# Altium schematic sheet 01 STM32.SchDoc
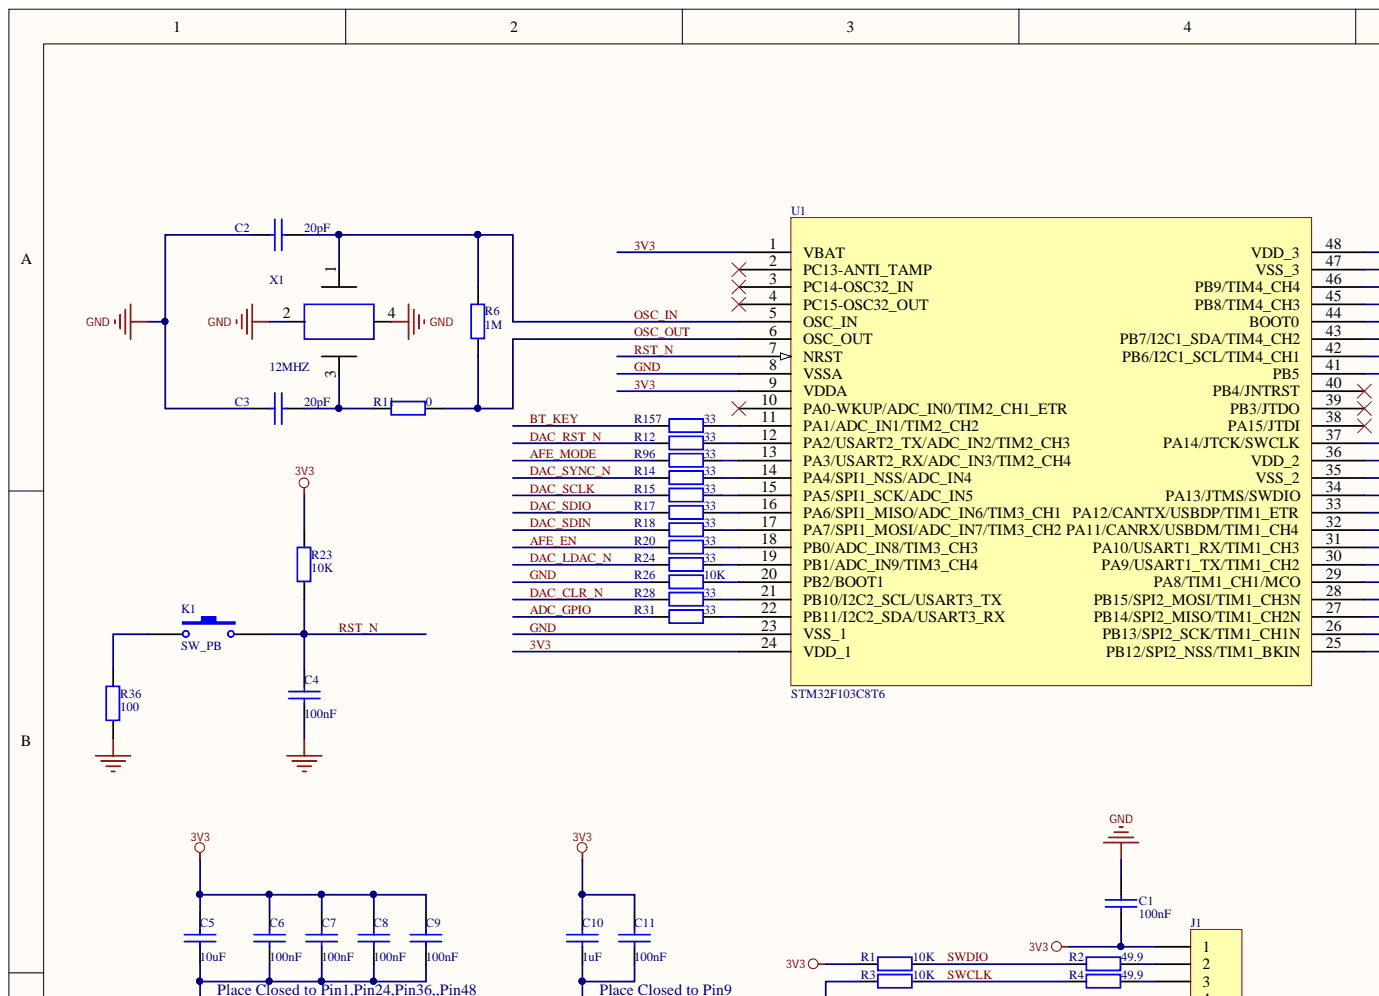
[diagram p0: 01 STM32.SchDoc, top left]
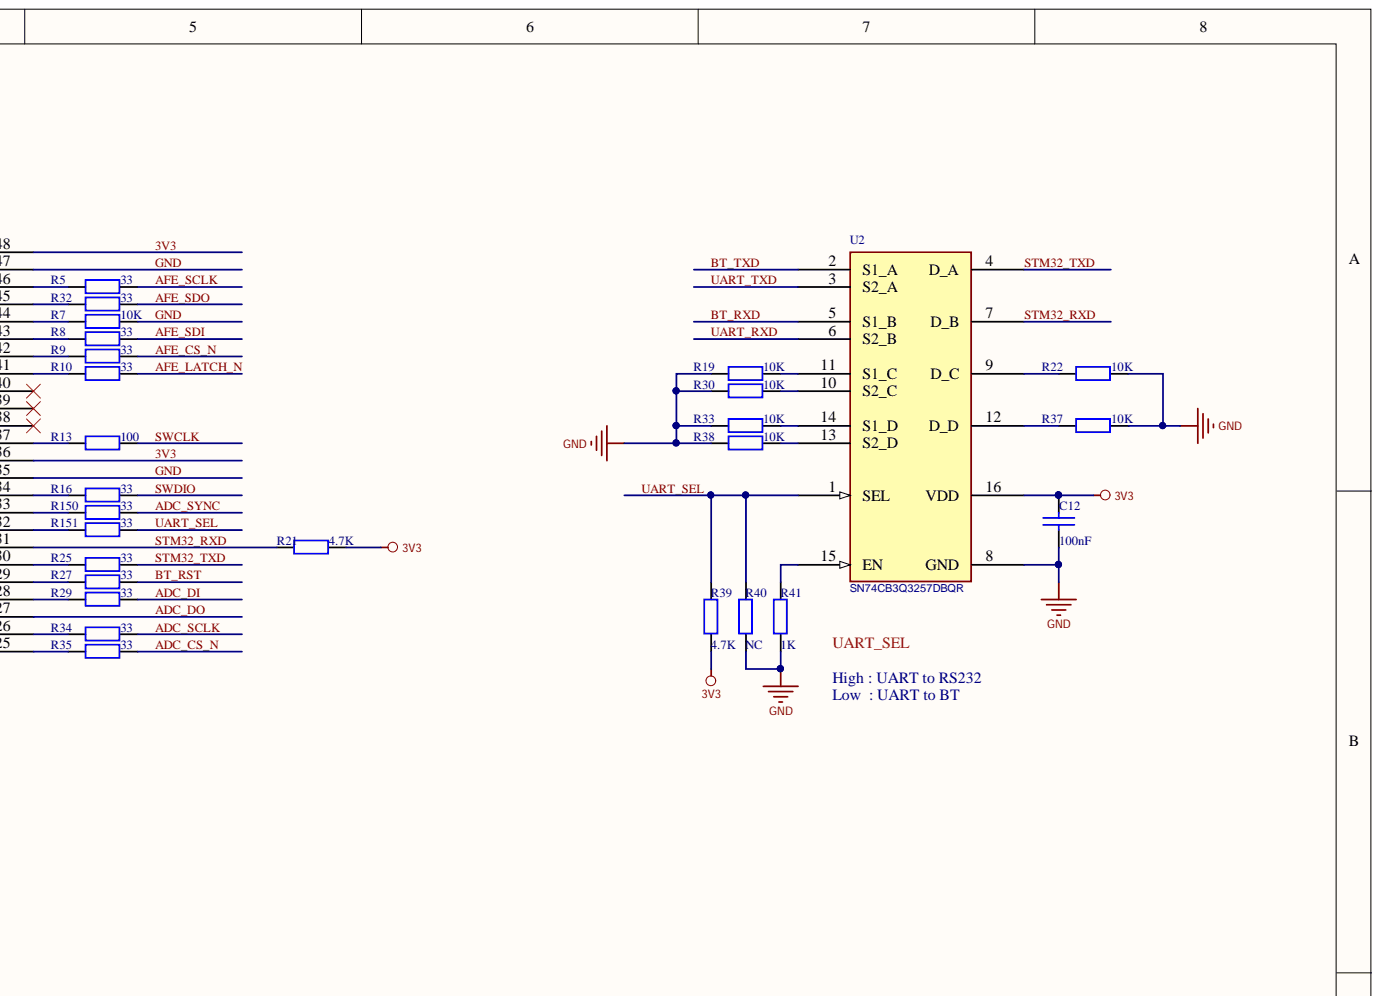
[diagram p1: 01 STM32.SchDoc, top right]
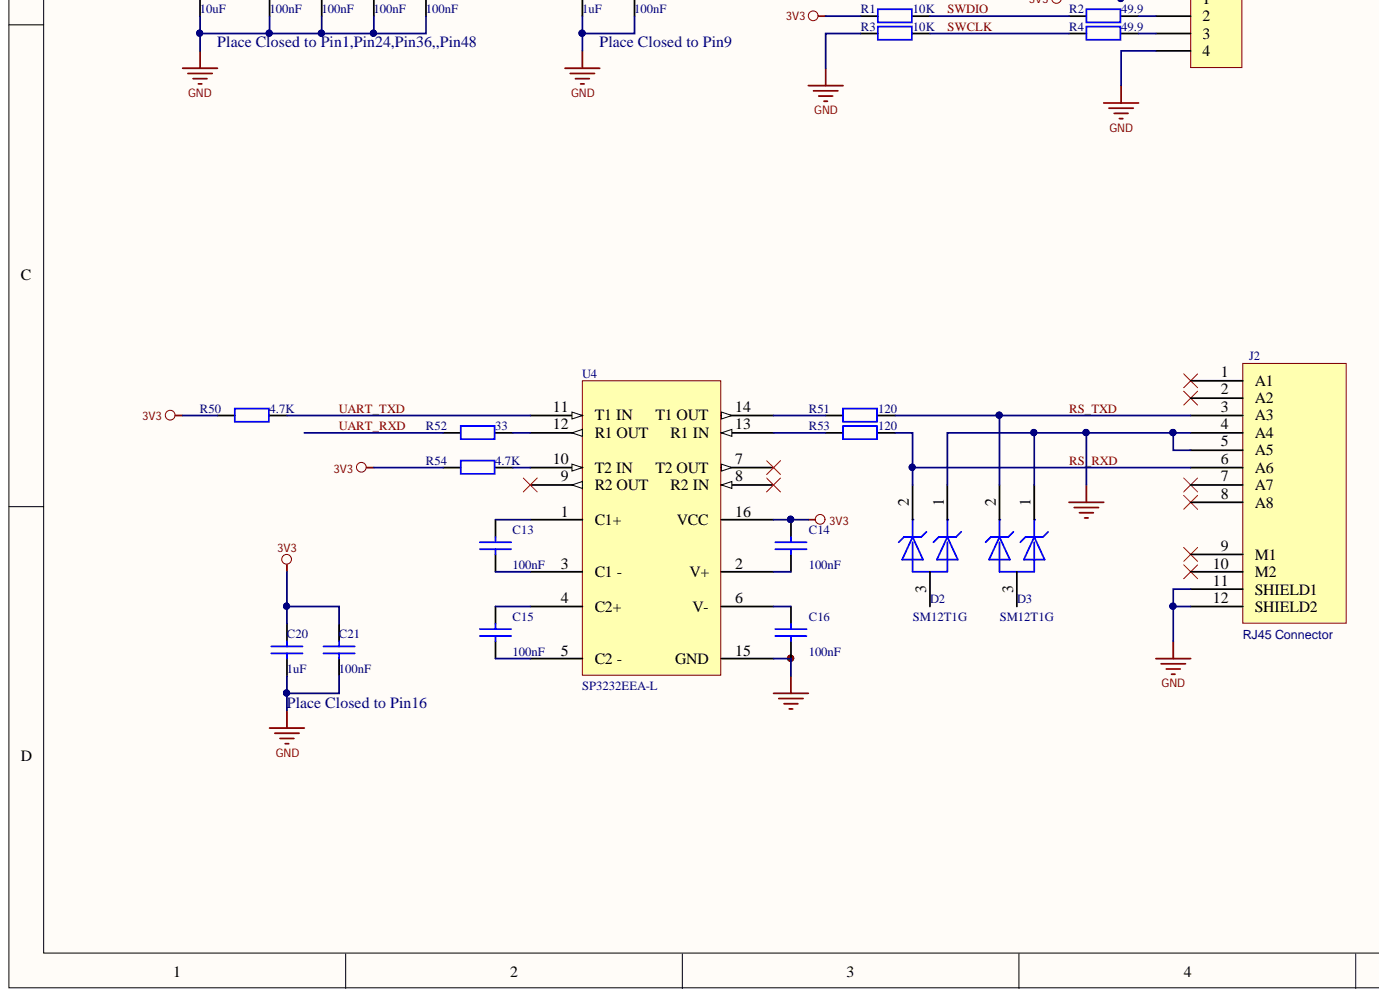
[diagram p2: 01 STM32.SchDoc, bottom left]
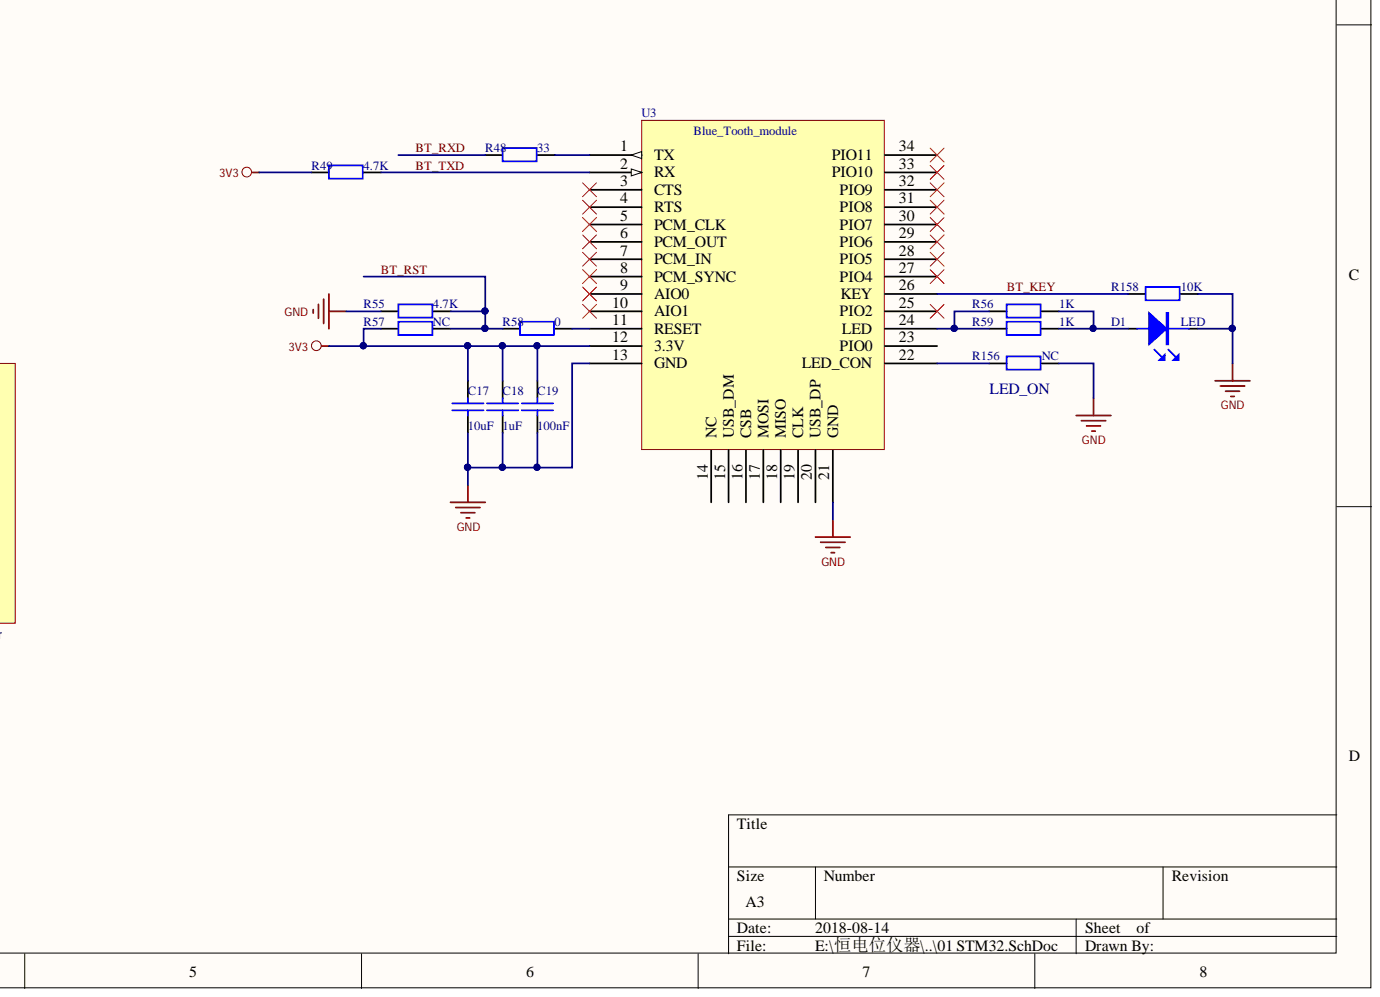
[diagram p3: 01 STM32.SchDoc, bottom right]

## Parts
C1  100nF  [SC0402]
C2  20pF  [SC0603]
C3  20pF  [SC0603]
C4  100nF  [SC0402]
C5  10uF  [SC0603]
C6  100nF  [SC0402]
C7  100nF  [SC0402]
C8  100nF  [SC0402]
C9  100nF  [SC0402]
C10  1uF  [SC0402]
C11  100nF  [SC0402]
C12  100nF  [SC0402]
C13  100nF  [SC0603]
C14  100nF  [SC0603]
C15  100nF  [SC0603]
C16  100nF  [SC0603]
C17  10uF  [SC0603]
C18  1uF  [SC0402]
C19  100nF  [SC0402]
C20  1uF  [SC0402]
C21  100nF  [SC0402]
D1  LED  [SD0603-LED]
D2  value SM12T1G  [SOT23]  (hidden comment: ZEN TVS ARRAY)
D3  value SM12T1G  [SOT23]  (hidden comment: ZEN TVS ARRAY)
J1  插针-4PIN-单排-2.54MM  [4PIN_254MM]  (value not drawn)
J2  RJ45 Connector  [MJ8-SRLFY]
K1  SW_PB  [SMT2]
R1  10K±1%  value 10K  [SR0402]
R2  49.9±1%  value 49.9  [SR0402]
R3  10K±1%  value 10K  [SR0402]
R4  value 49.9  [SR0402]  (hidden comment: 49.9±1%)
R5  value 33  [SR0402]  (hidden comment: 33±1%)
R6  value 1M  [SR0402]  (hidden comment: 1M±1%)
R7  value 10K  [SR0402]  (hidden comment: 10K±1%)
R8  value 33  [SR0402]  (hidden comment: 33±1%)
R9  value 33  [SR0402]  (hidden comment: 33±1%)
R10  value 33  [SR0402]  (hidden comment: 33±1%)
R11  value 0  [SR0402]  (hidden comment: 0±5%)
R12  value 33  [SR0402]  (hidden comment: 33±1%)
R13  value 100  [SR0402]  (hidden comment: 100±1%)
R14  value 33  [SR0402]  (hidden comment: 33±1%)
R15  value 33  [SR0402]  (hidden comment: 33±1%)
R16  value 33  [SR0402]  (hidden comment: 33±1%)
R17  value 33  [SR0402]  (hidden comment: 33±1%)
R18  value 33  [SR0402]  (hidden comment: 33±1%)
R19  10K±1%  value 10K  [SR0402]
R20  value 33  [SR0402]  (hidden comment: 33±1%)
R21  value 4.7K  [SR0402]  (hidden comment: 4.7K±1%)
R22  10K±1%  value 10K  [SR0402]
R23  value 10K  [SR0402]  (hidden comment: 10K±1%)
R24  value 33  [SR0402]  (hidden comment: 33±1%)
R25  value 33  [SR0402]  (hidden comment: 33±1%)
R26  value 10K  [SR0402]  (hidden comment: 10K±1%)
R27  value 33  [SR0402]  (hidden comment: 33±1%)
R28  value 33  [SR0402]  (hidden comment: 33±1%)
R29  value 33  [SR0402]  (hidden comment: 33±1%)
R30  10K±1%  value 10K  [SR0402]
R31  value 33  [SR0402]  (hidden comment: 33±1%)
R32  value 33  [SR0402]  (hidden comment: 33±1%)
R33  10K±1%  value 10K  [SR0402]
R34  value 33  [SR0402]  (hidden comment: 33±1%)
R35  value 33  [SR0402]  (hidden comment: 33±1%)
R36  value 100  [SR0402]  (hidden comment: 100±1%)
R37  value 10K  [SR0402]  (hidden comment: 10K±1%)
R38  10K±1%  value 10K  [SR0402]
R39  value 4.7K  [SR0402]  (hidden comment: 4.7K±1%)
R40  1K±1%  [SR0402]  (value not drawn)
R41  value 1K  [SR0402]  (hidden comment: 1K±1%)
R48  value 33  [SR0402]  (hidden comment: 33±1%)
R49  value 4.7K  [SR0402]  (hidden comment: 4.7K±1%)
R50  value 4.7K  [SR0402]  (hidden comment: 4.7K±1%)
R51  120±1%  value 120  [SR0603]
R52  value 33  [SR0402]  (hidden comment: 33±1%)
R53  120±1%  value 120  [SR0603]
R54  4.7K±1%  value 4.7K  [SR0402]
R55  value 4.7K  [SR0402]  (hidden comment: 4.7K±1%)
R56  value 1K  [SR0402]  (hidden comment: 1K±1%)
R57  4.7K±1%  [SR0402]  (value not drawn)
R58  value 0  [SR0402]  (hidden comment: 0±5%)
R59  value 1K  [SR0402]  (hidden comment: 1K±1%)
R96  value 33  [SR0402]  (hidden comment: 33±1%)
R150  value 33  [SR0402]  (hidden comment: 33±1%)
R151  value 33  [SR0402]  (hidden comment: 33±1%)
R156  4.7K±1%  [SR0402]  (value not drawn)
R157  value 33  [SR0402]  (hidden comment: 33±1%)
R158  value 10K  [SR0402]  (hidden comment: 10K±1%)
U1  STM32F103C8T6  [LQFP48]
U2  SN74CB3Q3257DBQR  [QSOP16]
U3  Blue_Tooth_module  [PKG_HC-06]
U4  SP3232EEA-L  [SSOP-16]
X1  12MHZ  [X288]

## Nets
3V3: C1.1 C5.2 C6.2 C7.2 C8.2 C9.2 C10.2 C11.2 C12.2 C14.1 C17.2 C18.2 C19.2 C20.2 C21.2 J1.1 R1.2 R21.2 R23.1 R39.2 R49.1 R50.1 R54.1 R57.1 U1.1(VBAT) U1.9(VDDA) U1.24(VDD_1) U1.36(VDD_2) U1.48(VDD_3) U2.16(VDD) U3.12(3.3V) U4.16(VCC)
ADC_CS_N: R35.2
ADC_DI: R29.2
ADC_DO: U1.27(PB14/SPI2_MISO/TIM1_CH2N)
ADC_GPIO: R31.1
ADC_SCLK: R34.2
ADC_SYNC: R150.2
AFE_CS_N: R9.2
AFE_EN: R20.1
AFE_LATCH_N: R10.2
AFE_MODE: R96.1
AFE_SCLK: R5.2
AFE_SDI: R8.2
AFE_SDO: R32.2
BT_KEY: R157.1 R158.2 U3.26(KEY)
BT_RST: R27.2 R55.2 R57.2 R58.1
BT_RXD: R48.1 U2.5(S1_B)
BT_TXD: R49.2 U2.2(S1_A) U3.2(RX)
DAC_CLR_N: R28.1
DAC_LDAC_N: R24.1
DAC_RST_N: R12.1
DAC_SCLK: R15.1
DAC_SDIN: R18.1
DAC_SDIO: R17.1
DAC_SYNC_N: R14.1
GND: C1.2 C2.1 C3.1 C4.1 C5.1 C6.1 C7.1 C8.1 C9.1 C10.1 C11.1 C12.1 C16.2 C17.1 C18.1 C19.1 C20.1 C21.1 D1.2 D2.1 D3.1 J1.4 J2.4(A4) J2.5(A5) J2.11(SHIELD1) J2.12(SHIELD2) R3.2 R7.1 R19.2 R22.1 R26.2 R30.2 R33.2 R36.1 R37.1 R38.2 R40.1 R41.1 R55.1 R156.2 R158.1 U1.8(VSSA) U1.23(VSS_1) U1.35(VSS_2) U1.47(VSS_3) U2.8(GND) U3.13(GND) U3.21(GND) U4.15(GND) X1.2 X1.4
OSC_IN: C2.2 R6.2 U1.5(OSC_IN) X1.1
OSC_OUT: R6.1 R11.2 U1.6(OSC_OUT)
RS_RXD: D2.2 J2.6(A6) R53.2
RS_TXD: D3.2 J2.3(A3) R51.2
RST_N: C4.2 K1.2 R23.2 U1.7(NRST)
STM32_RXD: R21.1 U1.31(PA10/USART1_RX/TIM1_CH3) U2.7(D_B)
STM32_TXD: R25.2 U2.4(D_A)
SWCLK: R3.1 R4.1 R13.1
SWDIO: R1.1 R2.1 R16.2
UART_RXD: R52.1 U2.6(S2_B)
UART_SEL: R39.1 R40.2 R151.2 U2.1(SEL)
UART_TXD: R50.2 U2.3(S2_A) U4.11(T1_IN)
NetC3_2: C3.2 R11.1 X1.3
NetC13_1: C13.1 U4.1(C1+)
NetC13_2: C13.2 U4.3(C1_-)
NetC14_2: C14.2 U4.2(V+)
NetC15_1: C15.1 U4.4(C2+)
NetC15_2: C15.2 U4.5(C2_-)
NetC16_1: C16.1 U4.6(V-)
NetD1_1: D1.1 R56.2 R59.2
NetJ1_2: J1.2 R2.2
NetJ1_3: J1.3 R4.2
NetK1_3: K1.3 R36.2
NetR5_1: R5.1 U1.46(PB9/TIM4_CH4)
NetR7_2: R7.2 U1.44(BOOT0)
NetR8_1: R8.1 U1.43(PB7/I2C1_SDA/TIM4_CH2)
NetR9_1: R9.1 U1.42(PB6/I2C1_SCL/TIM4_CH1)
NetR10_1: R10.1 U1.41(PB5)
NetR12_2: R12.2 U1.12(PA2/USART2_TX/ADC_IN2/TIM2_CH3)
NetR13_2: R13.2 U1.37(PA14/JTCK/SWCLK)
NetR14_2: R14.2 U1.14(PA4/SPI1_NSS/ADC_IN4)
NetR15_2: R15.2 U1.15(PA5/SPI1_SCK/ADC_IN5)
NetR16_1: R16.1 U1.34(PA13/JTMS/SWDIO)
NetR17_2: R17.2 U1.16(PA6/SPI1_MISO/ADC_IN6/TIM3_CH1)
NetR18_2: R18.2 U1.17(PA7/SPI1_MOSI/ADC_IN7/TIM3_CH2)
NetR19_1: R19.1 U2.11(S1_C)
NetR20_2: R20.2 U1.18(PB0/ADC_IN8/TIM3_CH3)
NetR22_2: R22.2 U2.9(D_C)
NetR24_2: R24.2 U1.19(PB1/ADC_IN9/TIM3_CH4)
NetR25_1: R25.1 U1.30(PA9/USART1_TX/TIM1_CH2)
NetR26_1: R26.1 U1.20(PB2/BOOT1)
NetR27_1: R27.1 U1.29(PA8/TIM1_CH1/MCO)
NetR28_2: R28.2 U1.21(PB10/I2C2_SCL/USART3_TX)
NetR29_1: R29.1 U1.28(PB15/SPI2_MOSI/TIM1_CH3N)
NetR30_1: R30.1 U2.10(S2_C)
NetR31_2: R31.2 U1.22(PB11/I2C2_SDA/USART3_RX)
NetR32_1: R32.1 U1.45(PB8/TIM4_CH3)
NetR33_1: R33.1 U2.14(S1_D)
NetR34_1: R34.1 U1.26(PB13/SPI2_SCK/TIM1_CH1N)
NetR35_1: R35.1 U1.25(PB12/SPI2_NSS/TIM1_BKIN)
NetR37_2: R37.2 U2.12(D_D)
NetR38_1: R38.1 U2.13(S2_D)
NetR41_2: R41.2 U2.15(EN)
NetR48_2: R48.2 U3.1(TX)
NetR51_1: R51.1 U4.14(T1_OUT)
NetR52_2: R52.2 U4.12(R1_OUT)
NetR53_1: R53.1 U4.13(R1_IN)
NetR54_2: R54.2 U4.10(T2_IN)
NetR56_1: R56.1 R59.1 U3.24(LED)
NetR58_2: R58.2 U3.11(RESET)
NetR96_2: R96.2 U1.13(PA3/USART2_RX/ADC_IN3/TIM2_CH4)
NetR150_1: R150.1 U1.33(PA12/CANTX/USBDP/TIM1_ETR)
NetR151_1: R151.1 U1.32(PA11/CANRX/USBDM/TIM1_CH4)
NetR156_1: R156.1 U3.22(LED_CON)
NetR157_2: R157.2 U1.11(PA1/ADC_IN1/TIM2_CH2)

Not connected: D2 3; D3 3; J2 1(A1) 2(A2) 7(A7) 8(A8) 9(M1) 10(M2); U1 2(PC13-ANTI_TAMP) 3(PC14-OSC32_IN) 4(PC15-OSC32_OUT) 10(PA0-WKUP/ADC_IN0/TIM2_CH1_ETR) 38(PA15/JTDI) 39(PB3/JTDO) 40(PB4/JNTRST); U3 3(CTS) 4(RTS) 5(PCM_CLK) 6(PCM_OUT) 7(PCM_IN) 8(PCM_SYNC) 9(AIO0) 10(AIO1) 14(NC) 15(USB_DM) 16(CSB) 17(MOSI) 18(MISO) 19(CLK) 20(USB_DP) 23(PIO0) 25(PIO2) 27(PIO4) 28(PIO5) 29(PIO6) 30(PIO7) 31(PIO8) 32(PIO9) 33(PIO10) 34(PIO11); U4 7(T2_OUT) 8(R2_IN) 9(R2_OUT)

## Library data of the parts
C1 C4 C6-C9 C11 C12 C19 C21 = CAP: Capacitor; Part_Comments: SMD陶瓷电容-10V-100nF-+/-10%-X7R-040; Code: 101024500028; COMPONENT_HIGH_MAX: 0.55MM; DEVICE: CC0402KRX5R6BB104; Vendor: Yageo; Voltage: 10V; Component Kind: Standard; Value: 100nF
C2 C3 = CAP: Capacitor; Part_Comments: SMD陶瓷电容-50V-20pF-+/-5%-NP0-0603; Code: 101024302001; DEVICE: CC0603JRNPO9BN200; Vendor: Yageo; Voltage: 50V; Component Kind: Standard; Value: 20pF
C5 C17 = CAP: Capacitor; Part_Comments: SMD陶瓷电容-6.3V-10uF-+/-20%-X5R-0603; Code: 101024500091; COMPONENT_HIGH_MAX: 0.9MM; DEVICE: CC0603MRX5R5BB106; Vendor: Yageo; Voltage: 6.3V; Component Kind: Standard; Value: 10uF
C10 C18 C20 = CAP: Capacitor; Part_Comments: SMD陶瓷电容-10V-1uF-+/-10%-X5R-0402; Code: 101024500093; COMPONENT_HIGH_MAX: 0.55MM; DEVICE: CC0402KRX5R6BB105; Vendor: Yageo; Voltage: 10V; Component Kind: Standard; Value: 1uF
C13-C16 = CAP: Capacitor; Part_Comments: SMD陶瓷电容-50V-100nF-+/-10%-X7R-0603; COMPONENT_HIGH_MAX: 0.55MM; DEVICE: CC0603KRX7R9BB104; Vendor: Yageo; Voltage: 50V; Component Kind: Standard; Value: 100nF
D1 = LED: 0603,贴片LED灯; Code: 101056485307; DEVICE: 19-21SYGC; Value: 19-21SYGC; Vendor: Everlight; Component Kind: Standard; Part_Comments: 0603,贴片LED灯
D2 D3 = SM12T1G: ESD 抑制器/TVS 二极管 ZEN TVS ARRAY 12V; Part_Comments: 瞬态抑制二极管-14.2V-15.8V-300W-0A-SOT-23; Vendor: ON Semiconductor; Component Kind: Standard; DEVICE: SM12T1G; Library Name: FAST_GC_FE.SCHLIB
J1 = Header 4: Header, 4-Pin; Value: SIP4; Component Kind: Standard; Library Name: Miscellaneous Connectors.IntLib; Part_Comments: 插针-4PIN-单排-2.54MM; Signal Integrity: Connector
J2 = MJ8-SL: Part_Comments: 网口连接器-单端口-8PIN-8BIT-屏蔽-模型插座/侧面插入型-引脚长度3.1MM-无LED灯/带定位脚; COMPONENT_HIGH_MAX: 13.3mm; DEVICE: 5921-T-D-R-10P8C-1C; Vendor: 方向电子; Component Kind: Standard; Description: RJ45 Connector; Library Name: Potentiostat.SchLib
K1 = SW_PB: Push-Button; Device: SKQYAAE010; Value: SKQYAAE010; Vendor: ALPS; Component Kind: Standard; Part_Comments: Push-Button; Library Name: FAST_GC_FE.SCHLIB
R1 R3 R7 R19 R22 R23 R26 R30 R33 R37 R38 R158 = RES2: Resistor; Part_Comments: 片式厚膜电阻器-0.0625W-10KOHM-+/-1%-0402; Code: 101017400055; DEVICE: RC0402FR-0710KL; Vendor: Yageo; Component Kind: Standard
R2 R4 = Res2: Resistor; Part_Comments: 片式厚膜电阻器-0.0625W-49.9OHM-+/-1%-0402; Code: 101017400041; DEVICE: RC0402FR-0749R9L; Vendor: Yageo; Component Kind: Standard; Library Name: Miscellaneous Devices.IntLib; Signal Integrity: Res; Simulation: RESISTOR
R5 R8-R10 R12 R14-R18 R20 R24 R25 R27-R29 R31 R32 R34 R35 R48 R52 R96 R150 R151 R157 = Res2: Resistor; Part_Comments: 片式厚膜电阻器-0.0625W-33OHM-+/-; Code: 101017400043; DEVICE: RC0402FR-0733RL; Vendor: Yageo; Component Kind: Standard; Library Name: Miscellaneous Devices.IntLib; Signal Integrity: Res; Simulation: RESISTOR
R6 = Res2: Resistor; Part_Comments: 片式厚膜电阻器-0.0625W-1MOHM-+/-1%-0402; Code: 101017400043; DEVICE: RC0402FR-071ML; Vendor: Yageo; Component Kind: Standard; Library Name: Miscellaneous Devices.IntLib; Signal Integrity: Res; Simulation: RESISTOR
R11 R58 = Res2: Resistor; Part_Comments: 片式厚膜电阻器-0.0625W-0OHM-< 50MOHM-0402-1A; Code: 101017400045; DEVICE: RC0402JR_070RL; Vendor: Yageo; Component Kind: Standard; Library Name: Miscellaneous Devices.IntLib; Signal Integrity: Res; Simulation: RESISTOR
R13 R36 = Res2: Resistor; Part_Comments: 片式厚膜电阻器-0.0625W-100OHM-+/-1%-0402; Code: 101017400046; DEVICE: RC0402FR-07100RL; Vendor: Yageo; Component Kind: Standard; Library Name: Miscellaneous Devices.IntLib; Signal Integrity: Res; Simulation: RESISTOR
R21 R39 R49 R50 R54 R55 = Res2: Resistor; Part_Comments: 片式厚膜电阻器-0.0625W-4.7KOHM-+/-1%-0402; Code: 101017400060; DEVICE: RC0402FR-074K7L; Vendor: Yageo; Component Kind: Standard; Library Name: Miscellaneous Devices.IntLib; Signal Integrity: Res; Simulation: RESISTOR
R40 = Res2: Resistor; Part_Comments: 片式厚膜电阻器-0.0625W-1KOHM-+/-1%-0402; Code: 101017400067; DEVICE: RC0402FR-071KL; Value: 1K; Vendor: Yageo; Component Kind: Standard; Library Name: Miscellaneous Devices.IntLib; NC: NC; Signal Integrity: Res; Simulation: RESISTOR
R41 R56 R59 = Res2: Resistor; Part_Comments: 片式厚膜电阻器-0.0625W-1KOHM-+/-1%-0402; Code: 101017400067; DEVICE: RC0402FR-071KL; Vendor: Yageo; Component Kind: Standard; Library Name: Miscellaneous Devices.IntLib; Signal Integrity: Res; Simulation: RESISTOR
R51 R53 = Res2: Resistor; Part_Comments: 片式厚膜电阻器-0.1W-120OHM-+/-1%-0603; Code: 101017301201; DEVICE: RC0603FR-07120RL; Vendor: Yageo; Component Kind: Standard; Library Name: Miscellaneous Devices.IntLib; Signal Integrity: Res; Simulation: RESISTOR
R57 R156 = Res2: Resistor; Part_Comments: 片式厚膜电阻器-0.0625W-4.7KOHM-+/-1%-0402; Code: 101017400060; DEVICE: RC0402FR-074K7L; Value: 4.7K; Vendor: Yageo; Component Kind: Standard; Library Name: Miscellaneous Devices.IntLib; NC: NC; Signal Integrity: Res; Simulation: RESISTOR
U1 = STM32F103C8T6: ARM 32位的Cortex-M3 CPU; Vendor: ST; Component Kind: Standard; Device: STM32F103C8T6; Part_Comments: ARM 32位的Cortex-M3 CPU; Value: STM32F103C8T6; Library Name: Potentiostat_VA.SCHLIB
U2 = SN74CB3Q3257DBQR: PARTS: 1; Code: 101000050128; DEVICE: TI:SN74CB3Q3257DBQR; LEVEL: STD; Component Kind: Standard; PKG_TYPE: QSOP16
U3 = HC_06: HC-06 蓝牙串口通信模块; Vendor: 广州汇承信息科技有限公司; Component Kind: Standard; Device: HC-06; Part_Comments: HC-06 蓝牙串口通信模块; Value: HC-06; Library Name: FAST_GC_Lib.SchLib
U4 = MAX232CSE: +-15KV ESD-PROTECTED, +5V RS-232 TRANSCEIVERS; Part_Comments: RS-232接口集成电路; Code: 101005003232; Vendor: Exar; Component Kind: Standard; DEVICE: SP3232EEA-L; Value: SP3232EEA-L
X1 = 4P_CRYSTAL: 石英晶体; Part_Comments: 晶体-12MHz-±30ppm-3225封装; CODE: 101050050066; COMPONENT_HIGH_MAX: 0.75MM; DEVICE: X2-3225-12MHz; Value: X2-3225-12MHz; Vendor: 泰艺电子; Component Kind: Standard; Library Name: FAST_GC_Lib.SchLib
